# Altium schematic sheet Schematic Prints("All Documents",Physical)
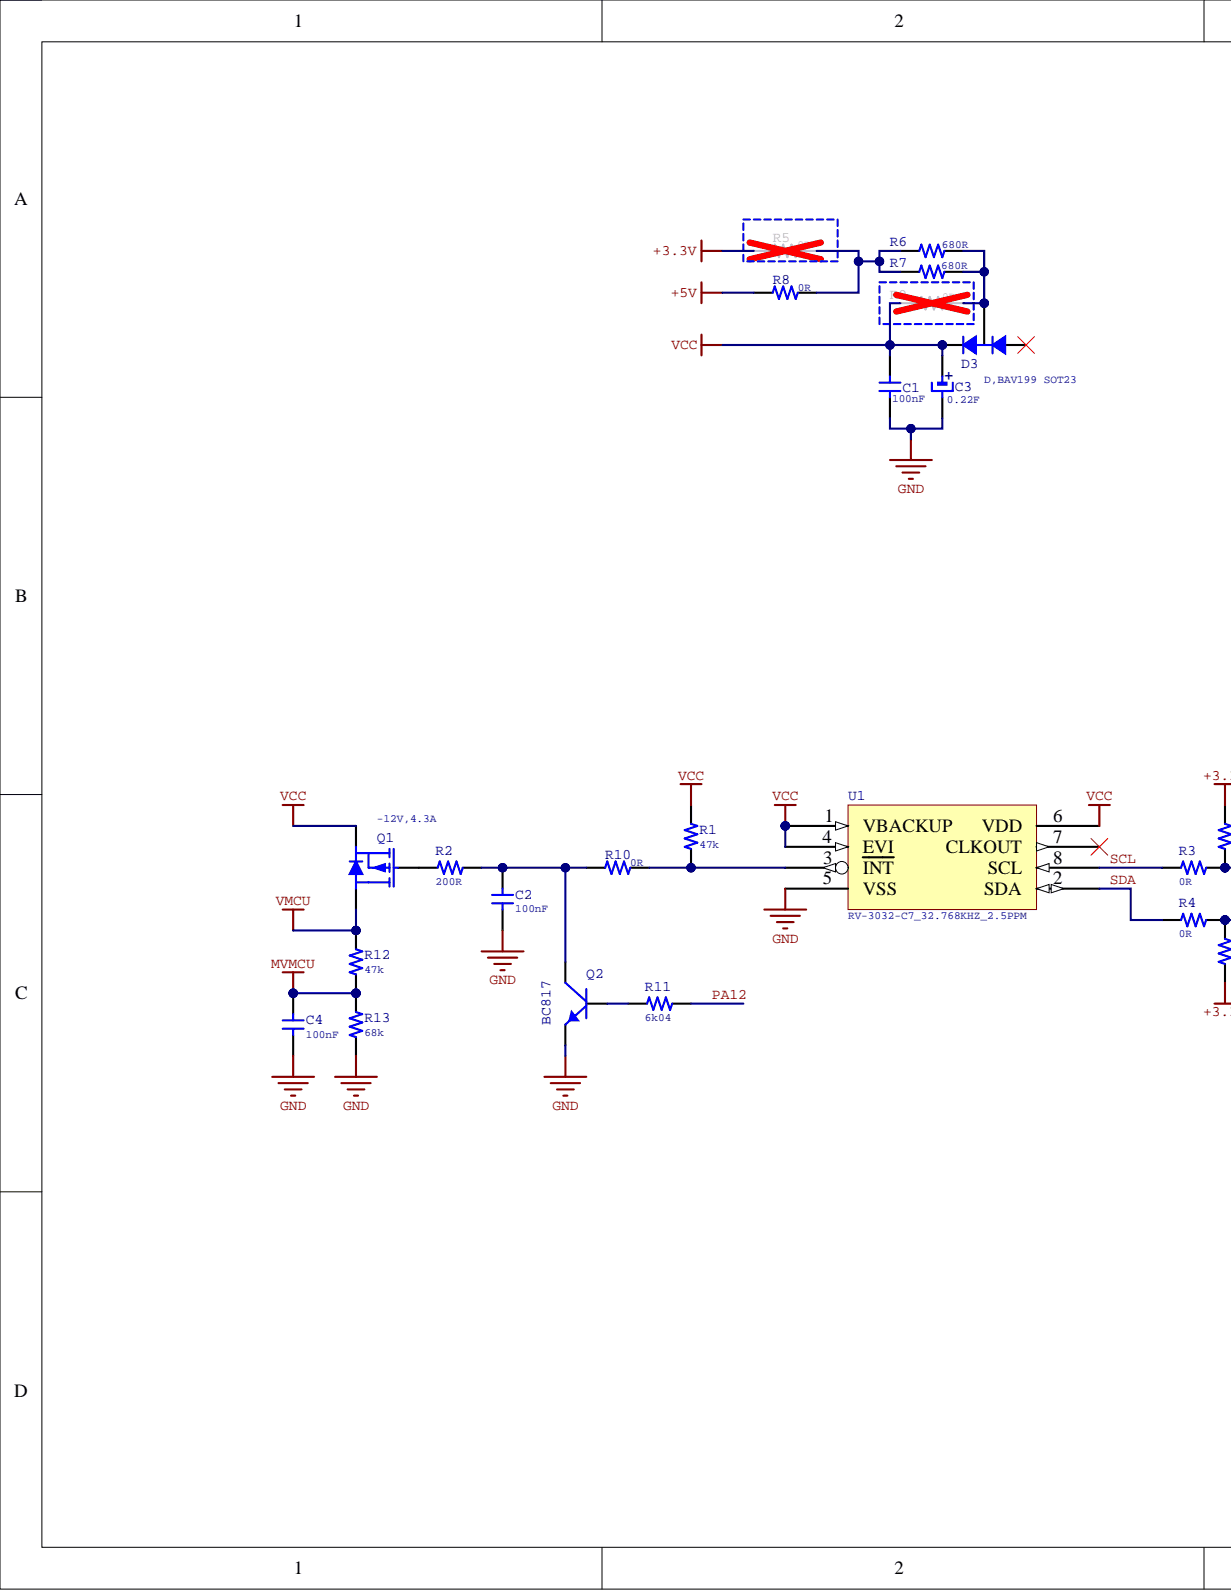
[diagram p0: Schematic Prints("All Documents",Physical), left]
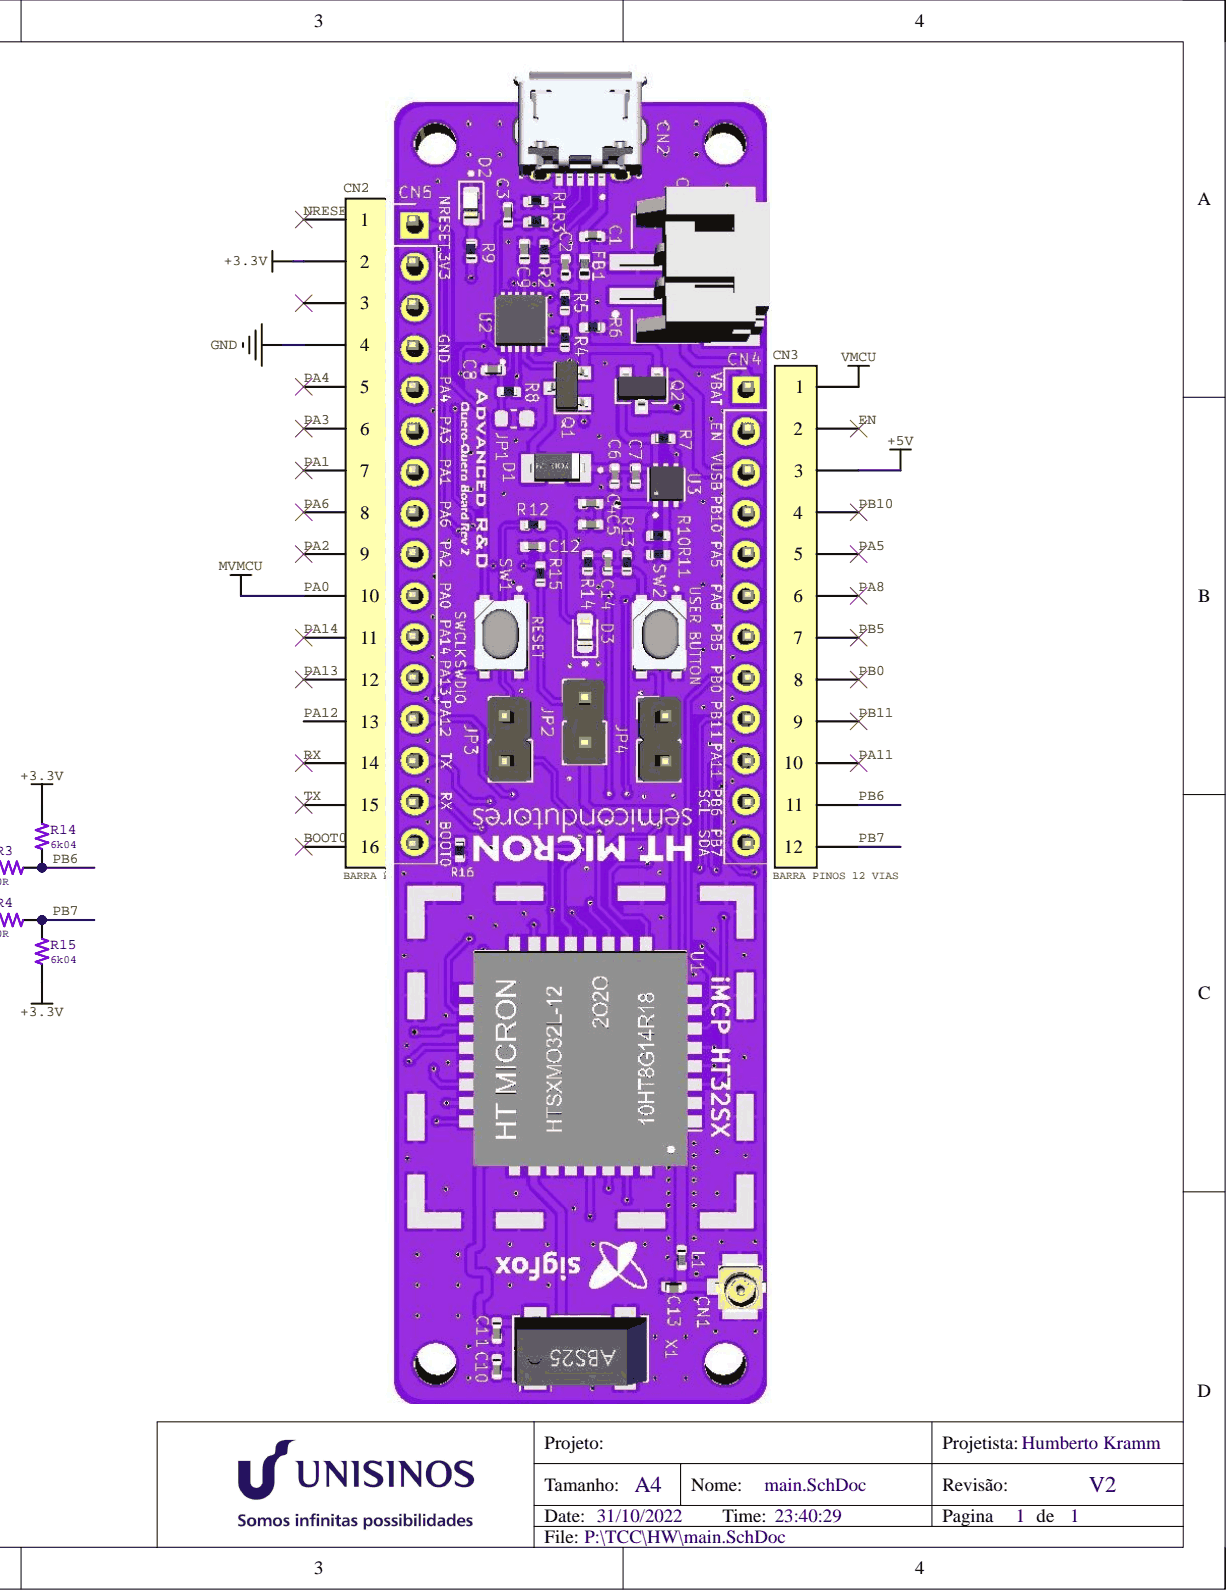
[diagram p1: Schematic Prints("All Documents",Physical), right]

## Parts
C1  100nF  [0402_C_1.1x0.6x0.6mm]
C2  100nF  [0402_C_1.1x0.6x0.6mm]
C3  0.22F  [CU13.5x9.5x5.0mm]
C4  100nF  [0402_C_1.1x0.6x0.6mm]
CN2  BARRA PINOS 16 VIAS  [CON BARRA 16 VIAS 180°]
CN3  BARRA PINOS 12 VIAS  [CON BARRA 12 VIAS 180°]
D3  D,BAV199 SOT23  [SOT23_1.11mm(1,2,3)]
Q1  -12V,4.3A  [SOT23_1.11mm(2,1,3)]
Q2  BC817  [SOT23_1.11mm(2,3,1)]
R1  47k  [0402_R1.1x0.6x0.4mm]
R2  200R  [SMD97 0402]
R3  0R  [SMD97 0402]
R4  0R  [SMD97 0402]
R5  0R  [SMD97 0402]
R6  680R  [SMD70]
R7  680R  [SMD70]
R8  0R  [SMD97 0402]
R9  0R  [SMD97 0402]
R10  0R  [SMD97 0402]
R11  6k04  [0402_R1.1x0.6x0.4mm]
R12  47k  [0402_R1.1x0.6x0.4mm]
R13  68k  [0402_R1.1x0.6x0.4mm]
R14  6k04  [0402_R1.1x0.6x0.4mm]
R15  6k04  [0402_R1.1x0.6x0.4mm]
U1  RV-3032-C7_32.768KHZ_2.5PPM  [XT_3.2x1.5x0.8mm]

## Nets
+3.3V: CN2.2 R5.1 R14.2 R15.1
+5V: CN3.3 R8.2
GND: C1.2 C2.2 C3.2 C4.2 CN2.4 Q2.3 R13.2 U1.5(VSS)
VCC: C1.1 C3.1 D3.2 Q1.1 R1.1 R9.2 U1.1(VBACKUP) U1.4(EVI) U1.6(VDD)
VMCU: CN3.1 Q1.3 R12.1
BOOT0: CN2.16
EN: CN3.2
NRESET: CN2.1
PA0: C4.1 CN2.10 R12.2 R13.1
PA1: CN2.7
PA2: CN2.9
PA3: CN2.6
PA4: CN2.5
PA5: CN3.5
PA6: CN2.8
PA8: CN3.6
PA11: CN3.10
PA12: CN2.13 R11.2
PA13: CN2.12
PA14: CN2.11
PB0: CN3.8
PB5: CN3.7
PB6: CN3.11 R3.1 R14.1
PB7: CN3.12 R4.1 R15.2
PB10: CN3.4
PB11: CN3.9
RX: CN2.14
SCL: R3.2 U1.8(SCL)
SDA: R4.2 U1.2(SDA)
TX: CN2.15
NetC2_1: C2.1 Q2.1 R2.2 R10.2
NetCN2_3: CN2.3
NetD3_1: D3.1
NetD3_3: D3.3 R6.1 R7.1 R9.1
NetQ1_2: Q1.2 R2.1
NetQ2_2: Q2.2 R11.1
NetR1_2: R1.2 R10.1 U1.3(INT)
NetR5_2: R5.2 R6.2 R7.2 R8.1
NetU1_7: U1.7(CLKOUT)

## Library data of the parts
C1 C2 C4 = C0402,100NF,50V,10%,X7R: C.CERAMICO 100NF,50V,10%,X7R, 0402, RoHS; Altura máx.: 0.6 mm; Código: 00.195.003-6; Montagem: SMD; Valor: 100000; Component Kind: Standard
C3 = C,SUPERCAP,0.22F,5.5V: C:SUPERCAP 0.22F/5.5V; Altura máx.: 9.5 mm; Código: 00.156.002-5; Montagem: PTH; Valor: 220000000; Component Kind: Standard
CN2 = CONECTOR P/ BARRA 16 VIAS 180°: CONECTOR BARRA DE PINOS 16 VIAS 180°; Component Kind: Standard
CN3 = CONECTOR P/ BARRA 12 VIAS 180º: CONECTOR BARRA DE PINOS 12 VIAS 180°; Component Kind: Standard
D3 = D,BAV199 SOT23: DIODO DUPLO 70V BAIXA FUGA, SOT23; Altura máx.: 1.11 mm; Código: 00.295.005-6; Montagem: SMD; Component Kind: Standard
Q1 = MOSFET,CANAL P,12V,4.3A,0.05R,SOT23: T.MOSFET P,12V,4.3A,0.05R,SOT23,RoHS; Altura máx.: 1.11 mm; Código: 00.293.013-6; Montagem: SMD; Component Kind: Standard
Q2 = BC817 SOT23: TRANSISTOR NPN 45V/500MA BC817, SMD; Altura máx.: 1.11 mm; Código: 00.248.004-1; Component Kind: Standard; Montagem: SMD
R1 R12 = R0402,47K,1/16W,1%: R:FILME 47K, 1/16W, 1%, SMD 0402, RoHS; Altura máx.: 0.40 mm; Código: 00.082.304-9; Valor: 698; Component Kind: Standard; Montagem: SMD
R2 = R0402,200R,1/16W,1%: R:FILME 200R, 1/16W, 1%,SMD 0402, RoHS; Altura máx.: 0.40 mm; Código: 00.082.260-3; Valor: 200; Component Kind: Standard; Montagem: SMD
R3-R5 R8-R10 = R0402,0R,1/16W,5%: R:FILME 0R, 1/16W, 5%,SMD 0402, RoHS; Código: 00.081.000-1; Valor: 0; Component Kind: Standard; Montagem: SMD
R6 R7 = R0805,680R,1/10W,5%: R:FILME 680R, 1/10W, 5%, SMD 0805; Código: 00.085.321-5; Valor: 680; Component Kind: Standard; Montagem: SMD
R11 R14 R15 = R0402,6K04,1/16W,1%: R:FILME 6k04, 1/16W, 1%, SMD 0402; Altura máx.: 0.40 mm; Código: 00.082.431-2; Valor: 6040; Component Kind: Standard; Montagem: SMD
R13 = R0402,68K,1/16W,1%: R:FILME 68K, 1/16W, 1%,  SMD 0402; Altura máx.: 0.4 mm; Código: 00.082.551-3; Valor: 68000; Component Kind: Standard; Montagem: SMD
U1 = RV-3032-C7_32.768KHZ_2.5PPM: CI PERIFERICO I2C RTC  COM XTCO; Código: 00.224.054-7; Component Kind: Standard; ComponentLink1Description: Cálculo
